# Altium schematic sheet Motor_Encoder.SchDoc
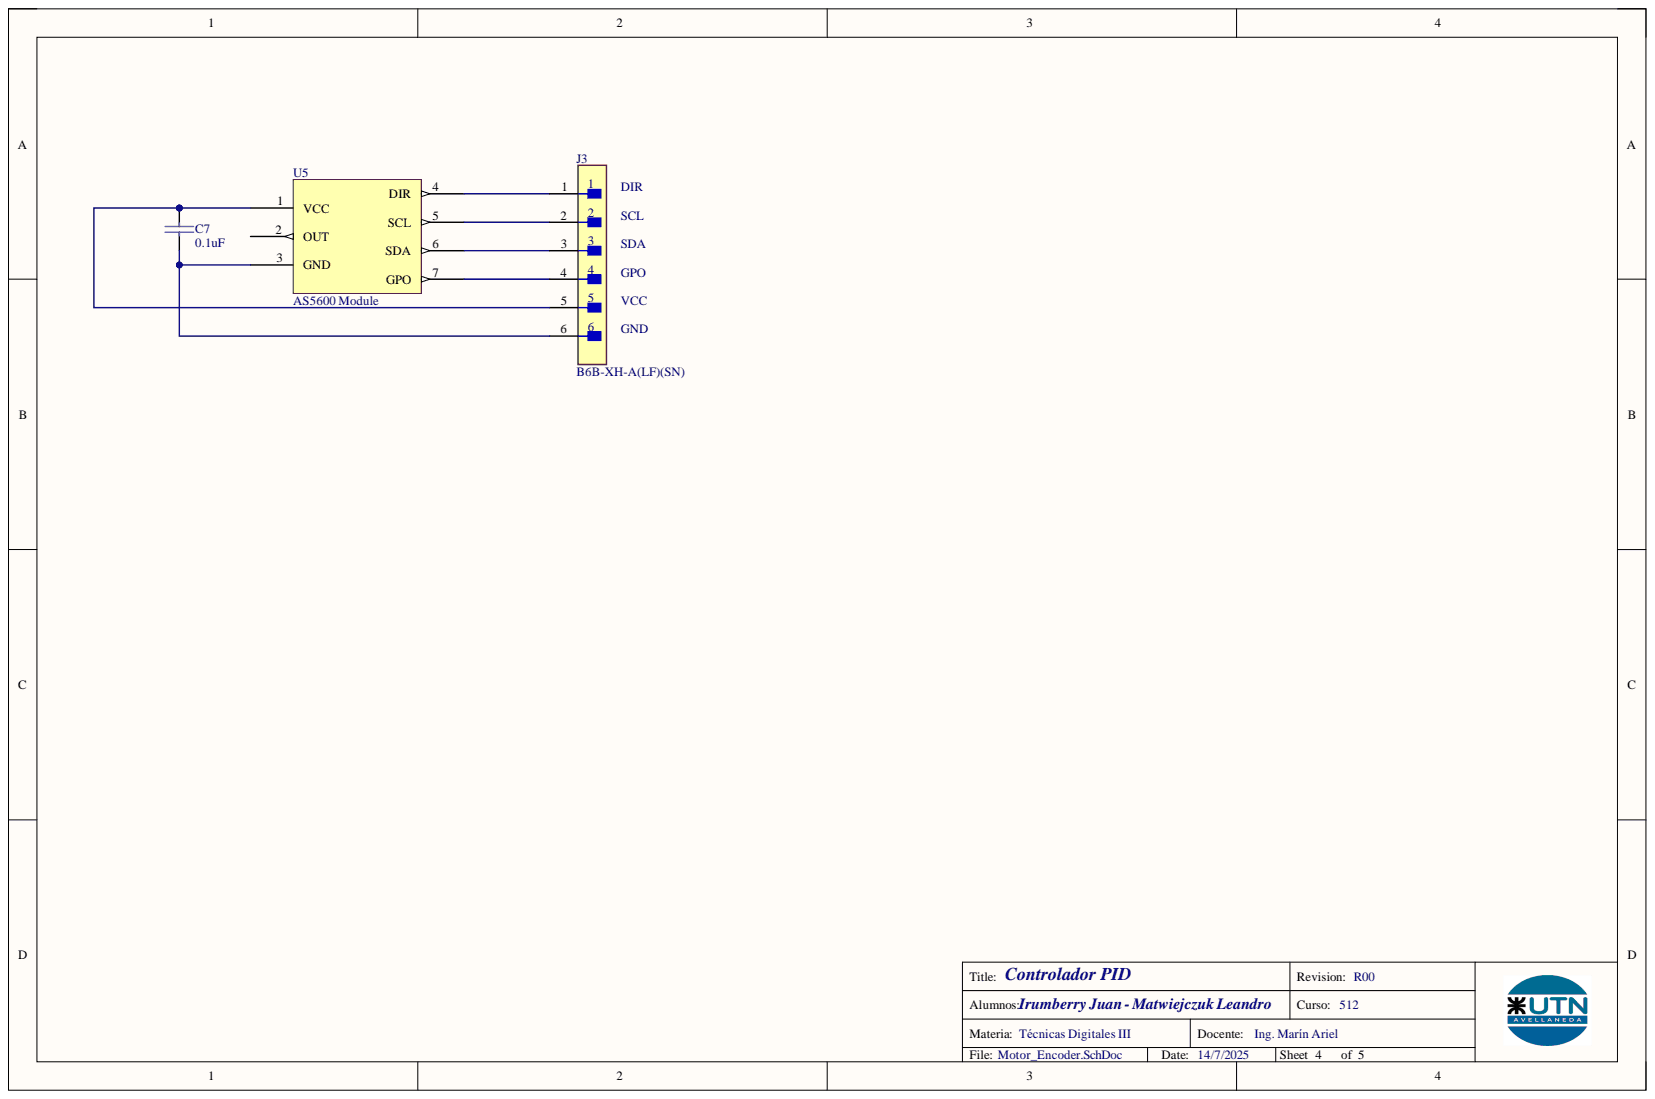
[diagram p0: Motor_Encoder.SchDoc]

## Parts
C7  0.1uF  [CAP_Axial_0.2mm]
J3  B6B-XH-A(LF)(SN)  [JST 6 PIN CONNECTOR]
U5  AS5600 Module  [AS5600 Module]

## Nets
NetC7_1: C7.1 J3.5 U5.1(VCC)
NetC7_2: C7.2 J3.6 U5.3(GND)
NetJ3_1: J3.1 U5.4(DIR)
NetJ3_2: J3.2 U5.5(SCL)
NetJ3_3: J3.3 U5.6(SDA)
NetJ3_4: J3.4 U5.7(GPO)

Not connected: U5 2(OUT)

## Library data of the parts
C7 = CAP_NP: Non-Polarized Capacitor; Component Kind: Standard; Library Name: ProyectoTD3.SchLib
J3 = JST 6 PIN: CONN HEADER VERT 6POS 2.5MM; Component Kind: Standard; Library Name: ProyectoTD3.SchLib
U5 = AS5600 Module: AS5600 Magnetic Angle Sensor Encoder Module; Component Kind: Standard; Library Name: ProyectoTD3.SchLib
